# Altium schematic sheet LaserController.SchDoc(LaserController)
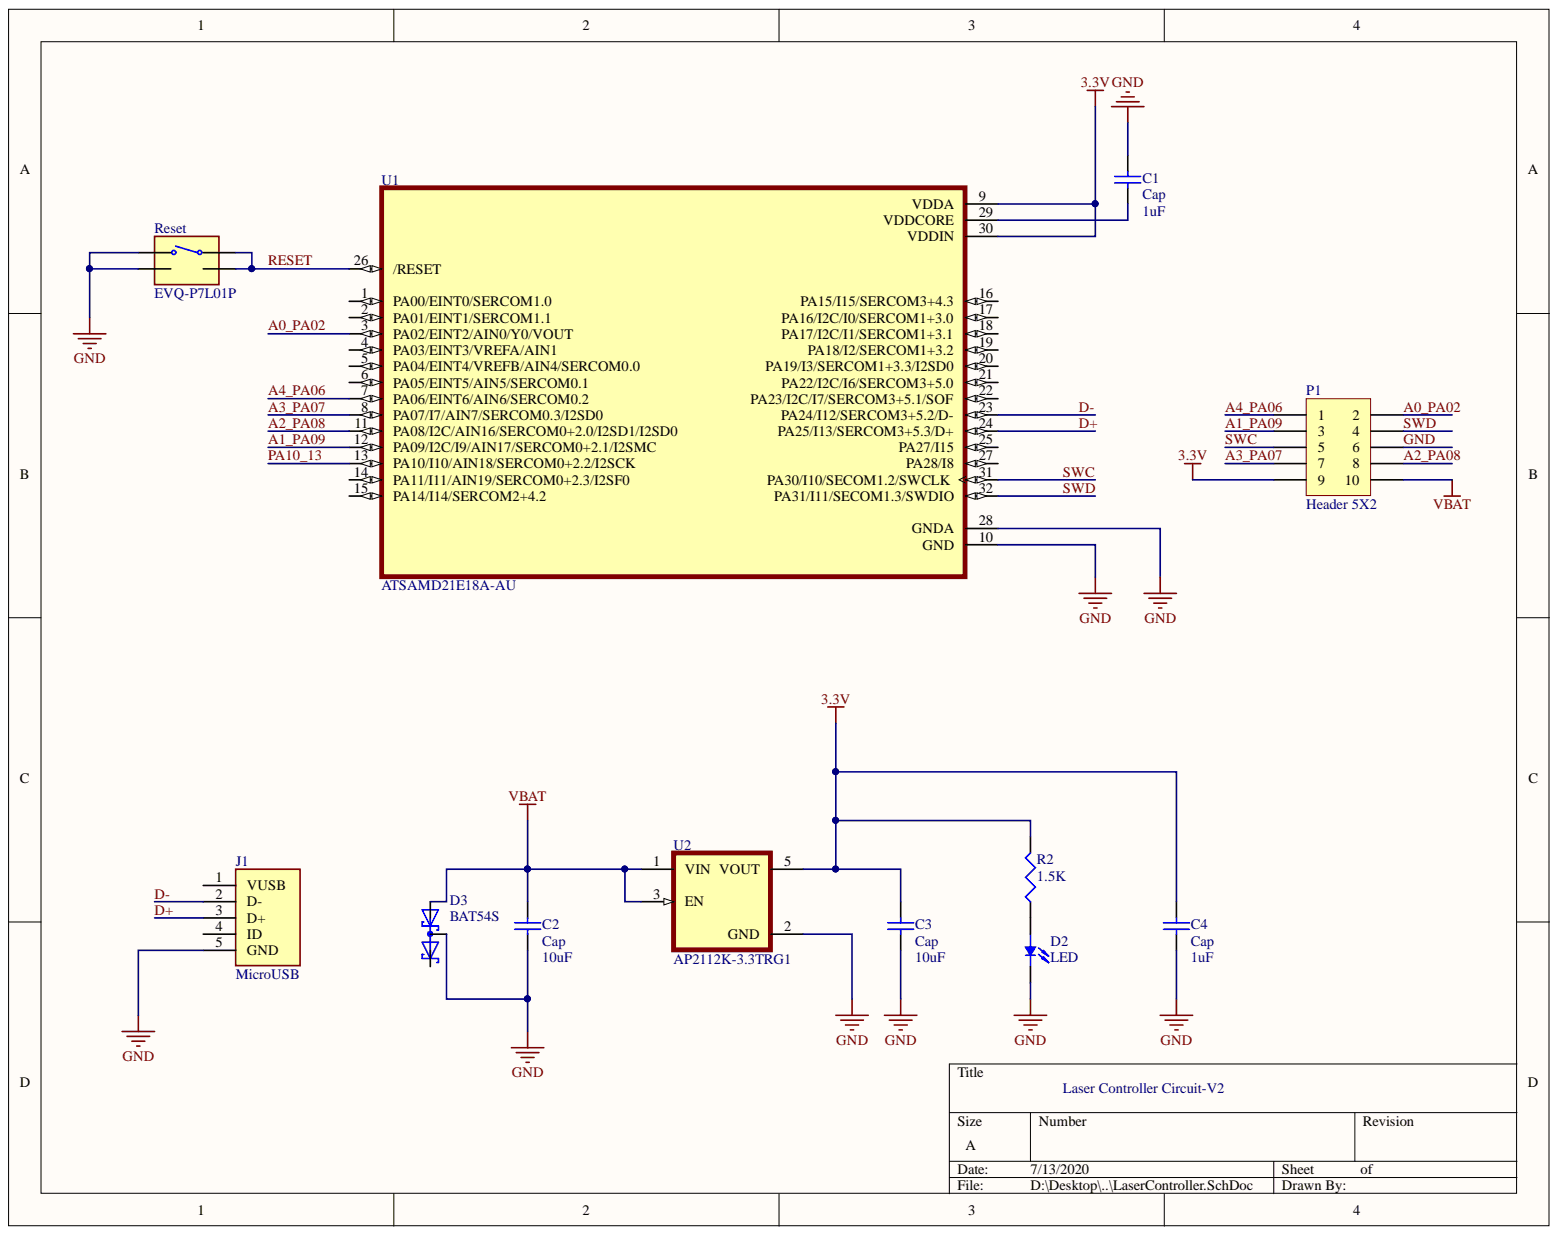
[diagram p0: LaserController.SchDoc]

## Parts
C1  Cap  value 1uF  [1608[0603]]
C2  Cap  value 10uF  [1608[0603]]
C3  Cap  value 10uF  [1608[0603]]
C4  Cap  value 1uF  [1608[0603]]
D2  LED  [DIO_SMLMN2WB1CW1C]
D3  BAT54S  [SOT23]
J1  MicroUSB  [FCI_10118193-0001LF]
P1  Header 5X2  [HDR2X5]
R2  1.5K  [J1-0603]
Reset  EVQ-P7L01P  [SW_PTS525SM15SMTR2LFS]
U1  ATSAMD21E18A-AU  [QFP80P900X900X120-32N]
U2  AP2112K-3.3TRG1  [SOT95P285X140-5N]

## Nets
3.3V: C3.2 C4.2 P1.9 R2.2 U1.9(VDDA) U1.30(VDDIN) U2.5(VOUT)
VBAT: C2.2 D3.1 P1.10 U2.1(VIN) U2.3(EN)
A0_PA02: P1.2 U1.3(PA02/EINT2/AIN0/Y0/VOUT)
A1_PA09: P1.3 U1.12(PA09/I2C/I9/AIN17/SERCOM0+2.1/I2SMC)
A2_PA08: P1.8 U1.11(PA08/I2C/AIN16/SERCOM0+2.0/I2SD1/I2SD0)
A3_PA07: P1.7 U1.8(PA07/I7/AIN7/SERCOM0.3/I2SD0)
A4_PA06: P1.1 U1.7(PA06/EINT6/AIN6/SERCOM0.2)
D+: J1.3(D+) U1.24(PA25/I13/SERCOM3+5.3/D+)
D-: J1.2(D-) U1.23(PA24/I12/SERCOM3+5.2/D-)
GND: C1.2 C2.1 C3.1 C4.1 D2.C D3.3 J1.5(GND) P1.6 Reset.1 Reset.2 U1.10(GND) U1.28(GNDA) U2.2(GND)
PA10_13: U1.13(PA10/I10/AIN18/SERCOM0+2.2/I2SCK)
RESET: Reset.3 Reset.4 U1.26(/RESET)
SWC: P1.5 U1.31(PA30/I10/SECOM1.2/SWCLK)
SWD: P1.4 U1.32(PA31/I11/SECOM1.3/SWDIO)
NetC1_1: C1.1 U1.29(VDDCORE)
NetD2_A: D2.A R2.1

Not connected: D3 2; J1 1(VUSB) 4(ID); U1 1(PA00/EINT0/SERCOM1.0) 2(PA01/EINT1/SERCOM1.1) 4(PA03/EINT3/VREFA/AIN1) 5(PA04/EINT4/VREFB/AIN4/SERCOM0.0) 6(PA05/EINT5/AIN5/SERCOM0.1) 14(PA11/I11/AIN19/SERCOM0+2.3/I2SF0) 15(PA14/I14/SERCOM2+4.2) 16(PA15/I15/SERCOM3+4.3) 17(PA16/I2C/I0/SERCOM1+3.0) 18(PA17/I2C/I1/SERCOM1+3.1) 19(PA18/I2/SERCOM1+3.2) 20(PA19/I3/SERCOM1+3.3/I2SD0) 21(PA22/I2C/I6/SERCOM3+5.0) 22(PA23/I2C/I7/SERCOM3+5.1/SOF) 25(PA27/I15) 27(PA28/I8)

## Library data of the parts
C1-C4 = Cap: Capacitor; Simulation: CAP; Signal Integrity: Cap; Published: 8-Jun-2000; PackageReference: RAD-0.3; PackageDescription: Radial Cap, Thru-Hole; 2 Leads; 0.3 in Pin Spacing; Library Name: Miscellaneous Devices.IntLib; LatestRevisionNote: Re-released for DXP Platform.; LatestRevisionDate: 17-Jul-2002; Component Kind: Standard; Publisher: Altium Limited
D2 = SMLMN2WB1CW1C: White LED Indication - Discrete 2.9V 0805 _2012 Metric_; MF: Rohm Semiconductor; SnapEDA_Link: https://www.snapeda.com/parts/SMLMN2WB1CW1C/Rohm/view-part/3217279/?ref=snap; Price: None; Package: 0805 Rohm Semiconductor; MP: SMLMN2WB1CW1C; Library Name: SMLMN2WB1CW1C.IntLib; Component Kind: Standard; Availability: Unavailable
D3 = BAT54S: Diode Array 1 Pair Series Connection Schottky 30V 200mA Surface Mount TO-236-3, SC-59, SOT-23-3; MF: ON Semiconductor; SnapEDA_Link: https://www.snapeda.com/parts/BAT54S/ON%20Semiconductor/view-part/1737355/?ref=snap; Price: None; Package: SOT-23-3 ON Semiconductor; MP: BAT54S; Library Name: BAT54S.IntLib; Component Kind: Standard; Availability: Unavailable
J1 = 10118193-0001LF: USB - micro B USB 2.0 Receptacle Connector 5 Position Surface Mount, Right Angle; Through Hole; MF: Amphenol ICC; SnapEDA_Link: https://www.snapeda.com/parts/10118193-0001LF/Amphenol%20FCI/view-part/2758989/?ref=snap; Price: None; Package: None; MP: 10118193-0001LF; Library Name: MicroUSB.IntLib; Component Kind: Standard; Availability: Unavailable
P1 = Header 5X2: Header, 5-Pin, Dual row; Signal Integrity: Connector; Library Name: Miscellaneous Connectors.IntLib; LatestRevisionNote: Re-released for DXP Platform.; LatestRevisionDate: 17-Jul-2002; Component Kind: Standard; Publisher: Altium Limited
R2 = Res3: Resistor; Simulation: RESISTOR; Signal Integrity: Res; Published: 8-Jun-2000; PackageReference: J1-0603; PackageDescription: Chip Resistor; Library Name: Miscellaneous Devices.IntLib; LatestRevisionNote: IPC-7351 Footprint Added.; LatestRevisionDate: 29-May-2009; Component Kind: Standard; Publisher: Altium Limited
Reset = EVQ-P7L01P: Tactile Switch SPST-NO Side Actuated Surface Mount, Right Angle; MF: Panasonic Electronic Components; SnapEDA_Link: https://www.snapeda.com/parts/EVQ-P7L01P/Panasonic%20Electronic%20Components/view-part/3622449/?ref=snap; Package: None; MP: EVQ-P7L01P; Library Name: Tactile Switch.IntLib; Digi-Key_Purchase_URL: https://www.digikey.co.nz/product-detail/en/panasonic-electronic-components/EVQ-P7L01P/P16769CT-ND/4429462?utm_source=snapeda&utm_medium=aggregator&utm_campaign=symbol; Digi-Key_Part_Number: P16769CT-ND; Component Kind: Standard
U1 = ATSAMD21E18A-AU: ARM庐 Cortex庐-M0+ SAM D21E Microcontroller IC 32-Bit 48MHz 256KB (256K x 8) FLASH 32-TQFP (7x7); MF: Microchip; SnapEDA_Link: https://www.snapeda.com/parts/ATSAMD21E18A-AU/Microchip/view-part/1758526/?ref=snap; Price: None; Package: TQFP-32 Microchip; MP: ATSAMD21E18A-AU; Mouser-Purchase-URL: https://snapeda.com/shop?store=Mouser&id=1758526; Library Name: SAMD21.IntLib; DigiKey-Purchase-URL: https://snapeda.com/shop?store=DigiKey&id=1758526; Component Kind: Standard; Availability: Unavailable
U2 = AP2112K-3.3TRG1: Linear Voltage Regulator IC 1 Output 600mA SOT-25; MF: Diodes Inc.; SnapEDA_Link: https://www.snapeda.com/parts/AP2112K-3.3TRG1/Diodes%20Inc./view-part/454988/?ref=snap; Package: SOT-753 Diodes Inc.; MP: AP2112K-3.3TRG1; Library Name: AP2112K-3.3TRG1.IntLib; Digi-Key_Purchase_URL: https://www.digikey.com.au/product-detail/en/diodes-incorporated/AP2112K-3.3TRG1/AP2112K-3.3TRG1DICT-ND/4505257?utm_source=snapeda&utm_medium=aggregator&utm_campaign=symbol; Digi-Key_Part_Number: AP2112K-3.3TRG1DICT-ND; Component Kind: Standard
